# Altium schematic sheet 220V_Control.SchDoc
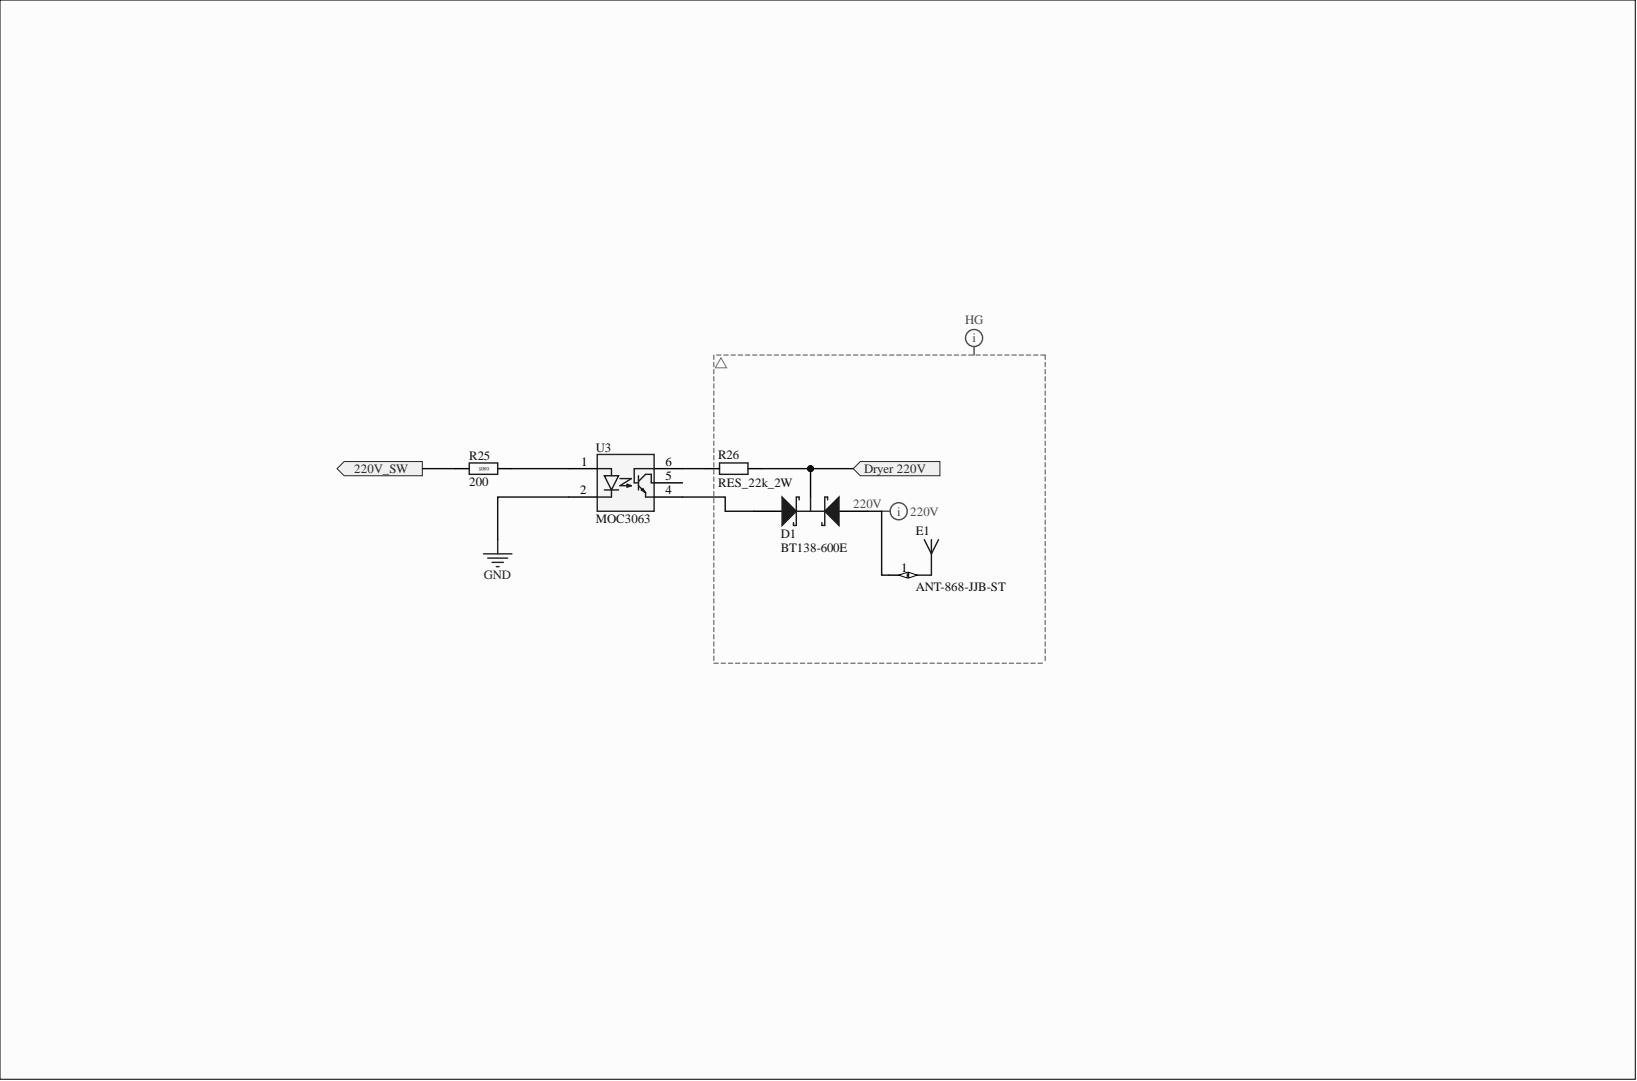
[diagram p0: 220V_Control.SchDoc]

## Parts
D1  BT138-600E  [TO-220]
E1  ANT-868-JJB-ST  [ANT-XXX-JJB-ST]
R25  value 200  [RESC2012-0805M]  (hidden comment: RESC_0805_R)
R26  RES_22k_2W  [PCBComponent_1]
U3  MOC3063  [DIP6-400]

## Ports
220V_SW, Dryer 220V

## Nets
GND: U3.2
220V_SW: R25.2 ; port 220V_SW
Dryer 220V: D1.2 R26.2 ; port Dryer 220V
NetD1_1: D1.1 E1.1
NetD1_3: D1.3 U3.4
NetR25_1: R25.1 U3.1
NetR26_1: R26.1 U3.6

Not connected: U3 5

## Library data of the parts
D1 = MBR20xx: Schottky Rectifier 20 A TO-220; Note: Pin 2 (cathode) is electrically connected to TAB; Component Kind: Standard; ComponentLink1Description: Manufacturer Link; ComponentLink1URL: http://www.irf.com/; ComponentLink2Description: Datasheet; ComponentLink2URL: http://www.irf.com/product-info/datasheets/data/mbr2035ct.pdf; DatasheetVersion: Dec-1997; LatestRevisionDate: 17-Jul-2002; LatestRevisionNote: Re-released for DXP Platform.; Library Name: Discrete.IntLib; PackageDescription: TO, Thru-Hole, Vertical, Heatsink Mounted; 3 In-Line Leads; Pitch 2.54 mm; PackageReference: TO-220AB; PackageVersion: Feb-1997; Published: 8-Jun-2000; Signal Integrity: MBR2035CT; Publisher: Altium Limited
E1 = ANT-868-JJB-ST: Embeddable 1/4-wave Monopole Antenna, JJB Series, 868MHz, 7mm Dia. Package, Straight; Component Kind: Standard; ComponentLink1Description: Manufacturer URL; ComponentLink1URL: http://www.linxtechnologies.com/; ComponentLink2Description: Datasheet; ComponentLink2URL: http://www.antennafactor.com/documents/ANT-868-JJB-xx_Data_Sheet.pdf; DatasheetVersion: 22/07/2008; Library Name: Connectors.IntLib; PackageDescription: Antenna, Monopole, JJB Series, Thru-Hole, Straight, 7.0 x 9.0 mm (Dia.xH typ); PackageReference: ANT-XXX-JJB-ST; PackageVersion: 22/07/2008
R25 = RESC_0805_R: Resistor 0805; Component Kind: Standard; Library Name: Passive.IntLib; Signal Integrity: Res; Simulation: RESISTOR
R26 = RES_22k_2W: Резистор 2 Вт; Component Kind: Standard; Library Name: Res_2W.IntLib
U3 = CNY17: CNY17 phototransistor optoclupler; Component Kind: Standard; Library Name: Discrete.IntLib
